# Altium schematic sheet DRAW.SchDoc
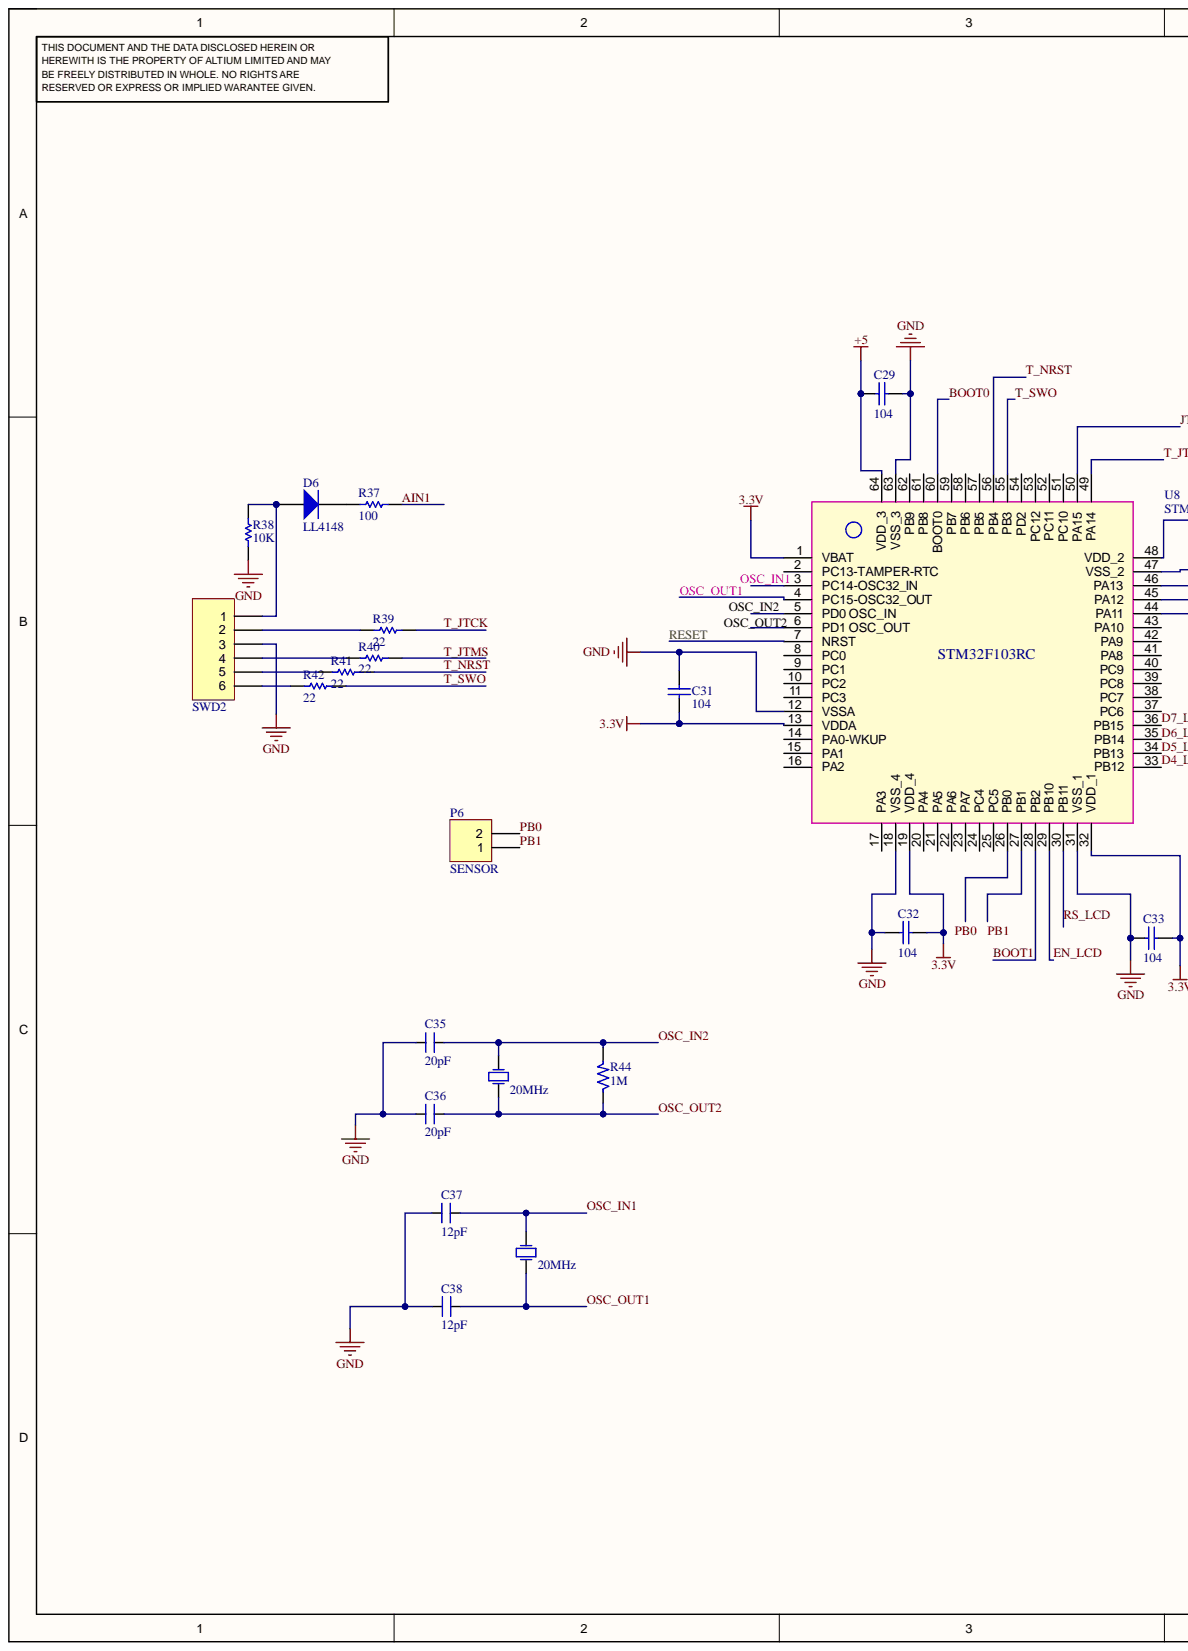
[diagram p0: DRAW.SchDoc, left]
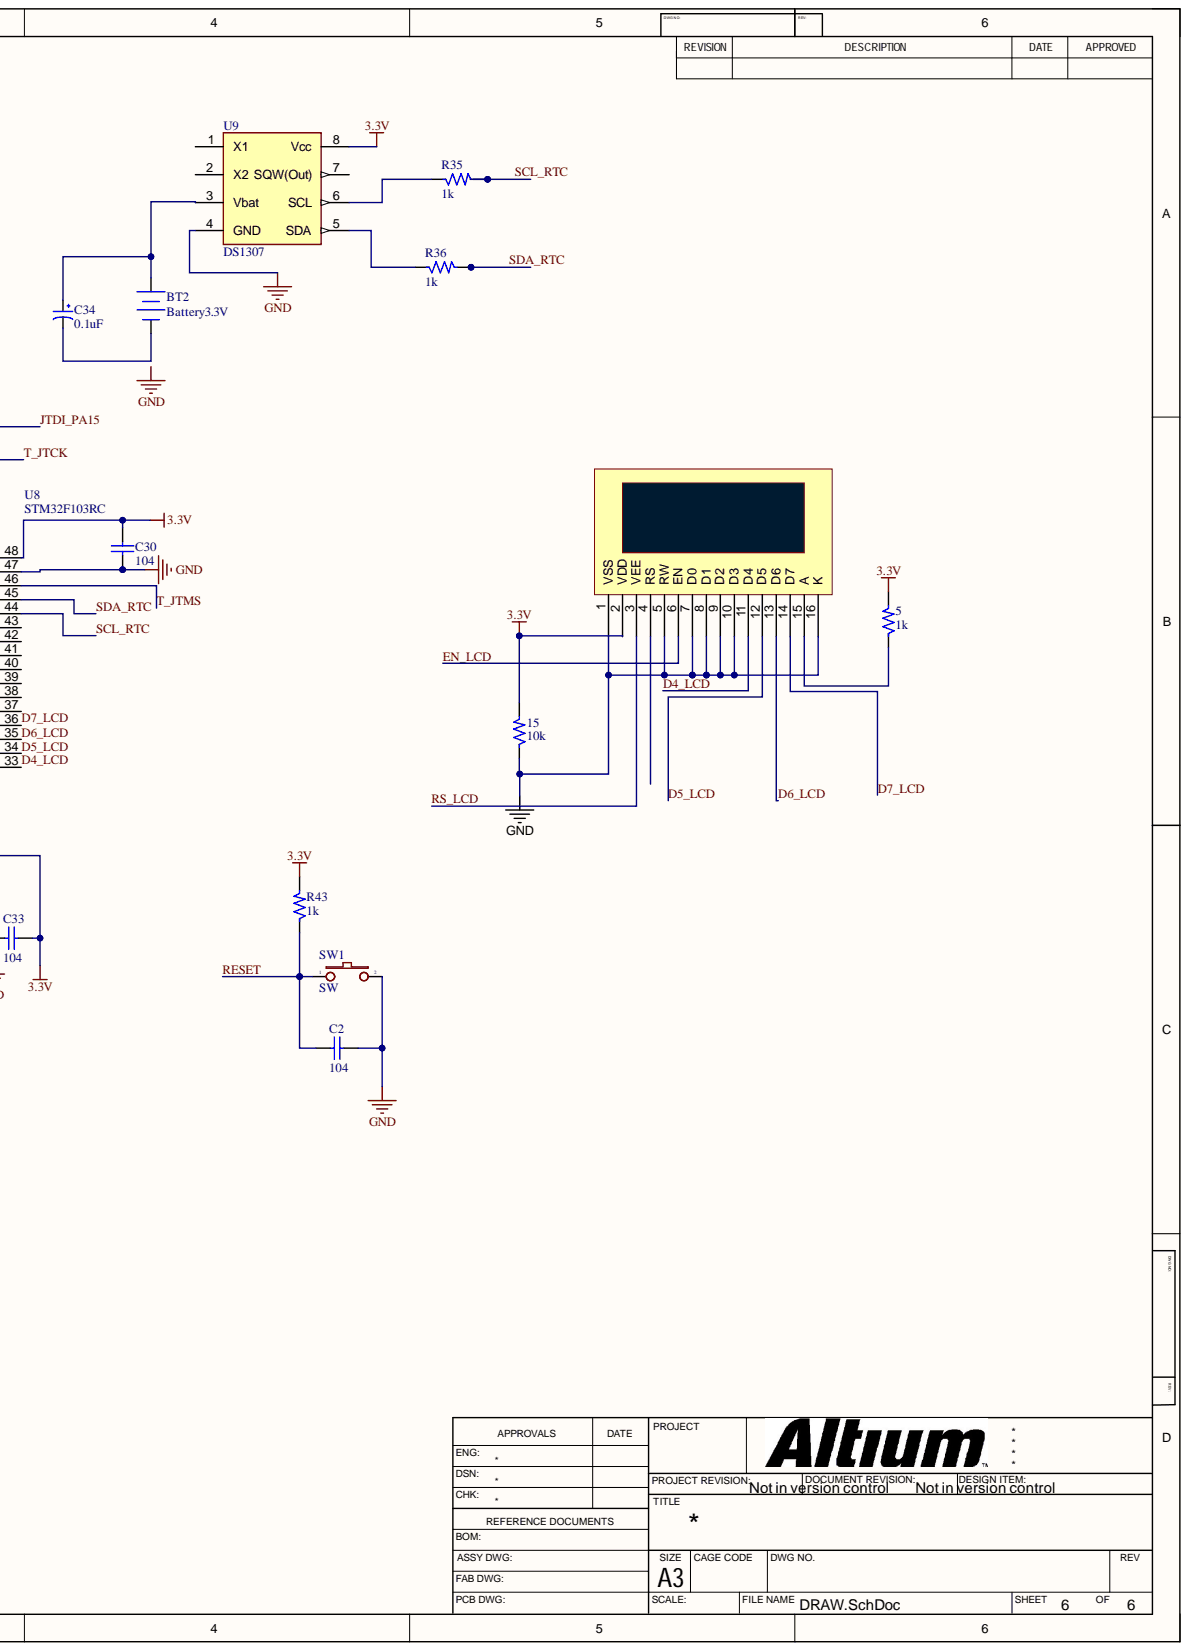
[diagram p1: DRAW.SchDoc, right]

## Parts
5  1k  [R1/6W]
15  10k  [R1/6W]
BT2  Battery3.3V  [CR2032 Holder]
C2  104  [CAP104]
C29  104  [0805]
C30  104  [0805]
C31  104  [0805]
C32  104  [0805]
C33  104  [0805]
C34  0.1uF  [CP1/2]
C35  20pF  [CN1]
C36  20pF  [CN1]
C37  12pF  [CN1]
C38  12pF  [CN1]
D6  LL4148  [SOD-80]
P6  SENSOR  [HDR1X2H]
R35  1k  [R1/6W]
R36  1k  [R1/6W]
R37  100  [R0603]
R38  10K  [R0603]
R39  22  [R0603]
R40  22  [R0603]
R41  22  [R0603]
R42  22  [R0603]
R43  1k  [R1/6W]
R44  1M  [R1/6W]
SW1  SW  [SW2]
SWD2  CONN-6  [XH-6]  (value not drawn)
U8  STM32F103RC  [STM32F103RC_TSQFP]
U9  DS1307  [DIP.100/8/W.300/L.400]
Y2  20MHz  [XT2]
Y3  20MHz  [XT2]
  LCD  [LCD16X2]  (value not drawn)

## Nets
3.3V: 5.2 15.2 C30.1 C31.1 C32.1 C33.1 R43.2 U8.1(VBAT) U8.13(VDDA) U8.19(VDD_4) U8.32(VDD_1) U8.48(VDD_2) U9.8(Vcc) .2(VDD)
+5: C29.1 U8.64(VDD_3)
GND: 15.1 C2.2 C29.2 C30.2 C31.2 C32.2 C33.2 C35.1 C36.1 C37.1 C38.1 R38.2 SW1.2 SWD2.3 U8.12(VSSA) U8.18(VSS_4) U8.31(VSS_1) U8.47(VSS_2) U8.63(VSS_3) U9.4(GND) .1(VSS) .5(RW) .7(D0) .8(D1) .9(D2) .10(D3) .16(K)
Net_4: .4(RS)
AIN1: R37.2
BOOT0: U8.60(BOOT0)
BOOT1: U8.28(PB2)
D4_LCD: U8.33(PB12) .11(D4)
D5_LCD: U8.34(PB13) .12(D5)
D6_LCD: U8.35(PB14) .13(D6)
D7_LCD: U8.36(PB15) .14(D7)
EN_LCD: U8.29(PB10) .6(EN)
JTDI_PA15: U8.50(PA15)
OSC_IN1: C37.2 Y3.2
OSC_IN2: C35.2 R44.2 Y2.2
OSC_OUT1: C38.2 U8.4(PC15-OSC32_OUT) Y3.1
OSC_OUT2: C36.2 R44.1 Y2.1
PB0: P6.2
PB1: P6.1
RESET: C2.1 R43.1 SW1.1 U8.7(NRST)
RS_LCD: U8.30(PB11) .3(VEE)
SCL_RTC: R35.2 U8.44(PA11)
SDA_RTC: R36.2 U8.45(PA12)
T_JTCK: R39.1 U8.49(PA14)
T_JTMS: R40.1 U8.46(PA13)
T_NRST: R41.1 U8.56(PB4)
T_SWO: R42.1 U8.55(PB3)
Net5_1: 5.1 .15(A)
NetBT2_1: BT2.1 C34.1 U9.3(Vbat)
NetBT2_2: BT2.2 C34.2
NetD6_1: D6.1 R38.1 SWD2.1
NetD6_2: D6.2 R37.1
NetR35_1: R35.1 U9.6(SCL)
NetR36_1: R36.1 U9.5(SDA)
NetR39_2: R39.2 SWD2.2
NetR40_2: R40.2 SWD2.4
NetR41_2: R41.2 SWD2.5
NetR42_2: R42.2 SWD2.6

Not connected: U8 2(PC13-TAMPER-RTC) 3(PC14-OSC32_IN) 5(PD0_OSC_IN) 6(PD1_OSC_OUT) 8(PC0) 9(PC1) 10(PC2) 11(PC3) 14(PA0-WKUP) 15(PA1) 16(PA2) 17(PA3) 20(PA4) 21(PA5) 22(PA6) 23(PA7) 24(PC4) 25(PC5) 26(PB0) 27(PB1) 37(PC6) 38(PC7) 39(PC8) 40(PC9) 41(PA8) 42(PA9) 43(PA10) 51(PC10) 52(PC11) 53(PC12) 54(PD2) 57(PB5) 58(PB6) 59(PB7) 61(PB8) 62(PB9); U9 1(X1) 2(X2) 7(SQW(Out))

## Library data of the parts
5 15 R35 R36 R43 R44 = Res: Resistor 1/4W; Value: R1/4W - 1K; Component Kind: Standard; Library Name: Cty1.SchLib
BT2 = Battery3.3V: Multicell Battery; Component Kind: Standard; LatestRevisionDate: 17-Jul-2002; LatestRevisionNote: Re-released for DXP Platform.; Library Name: Cty1.SchLib; PackageReference: BAT-2; Published: 24-Mar-1999; Publisher: Altium Limited
C2 = Cap 104: Capacitor (Semiconductor SIM Model); Value: 0.1uF; Component Kind: Standard; LatestRevisionDate: 17-Jul-2002; LatestRevisionNote: Re-released for DXP Platform.; Library Name: Cty1.SchLib; PackageReference: C1206; Published: 8-Jun-2000; Signal Integrity: Cap Semi; Simulation: CAP; Publisher: Altium Limited
C29-C33 = Cap 104_SMD: Capacitor (Semiconductor SIM Model); Value: 0.1uF; Component Kind: Standard; LatestRevisionDate: 17-Jul-2002; LatestRevisionNote: Re-released for DXP Platform.; Library Name: Cty1.SchLib; PackageReference: C1206; Published: 8-Jun-2000; Signal Integrity: Cap Semi; Simulation: CAP; Publisher: Altium Limited
C34 = CP1: Cap P  0,1uF < 22uF , 16 - 50V; Description 1: 10 => 220uF/6,3V/10V - 10uF => 100uF/16V; Description 2: 0,1uF => 33uF/50V - 10uF => 22uF/63V; Description 3: 0,47uF => 10uF/100V; Value: 10uF/50V; Component Kind: Standard; Library Name: Cty1.SchLib
C35-C38 = CN1: Cap np  1pF - 472pF; Value: 100pF; Component Kind: Standard; Library Name: Cty1.SchLib
P6 = Header 2H: Header, 2-Pin, Right Angle; Component Kind: Standard; LatestRevisionDate: 17-Jul-2002; LatestRevisionNote: Re-released for DXP Platform.; Library Name: Cty1.SchLib; Signal Integrity: Connector; Publisher: Altium Limited
R37-R42 = Resistor: Designer: Parsa Malekpour; Component Kind: Standard; Description: Resistor; Library Name: ParsaLibrary.SchLib
  R37: Value: 100
  R38: Value: 10K
  R39-R42: Value: 22
SW1 = SW2: Switch button 2 pin; Value: SW chip 2 pin; Component Kind: Standard; Library Name: Cty1.SchLib
U8 = STM32F103RC: Performance line, ARM-based 32-bit MCU with up to 512 KB Flash,  USB, CAN, 11 timers, 3 ADCs and 13 communication interfaces; Component Kind: Standard; Library Name: Cty1.SchLib; Value: STM32F103RC
U9 = DS1307: 64 X 8 Serial Real-Time Clock; Component Kind: Standard; Library Name: Cty1.SchLib
Y2 Y3 = Crystal: Crystal Oscillator; Component Kind: Standard; LatestRevisionDate: 17-Jul-2002; LatestRevisionNote: Re-released for DXP Platform.; Library Name: Cty1.SchLib; PackageReference: R38; Published: 8-Jun-2000; Publisher: Altium Limited
 = LCD: Value: LCD16x2; Component Kind: Standard; Library Name: Cty1.SchLib
D6 = LL4148: Component Kind: Standard; Library Name: ParsaLibrary.SchLib
SWD2 = CONN-6: Component Kind: Standard; Library Name: ParsaLibrary.SchLib
